# Altium schematic sheet Sheet1.SchDoc
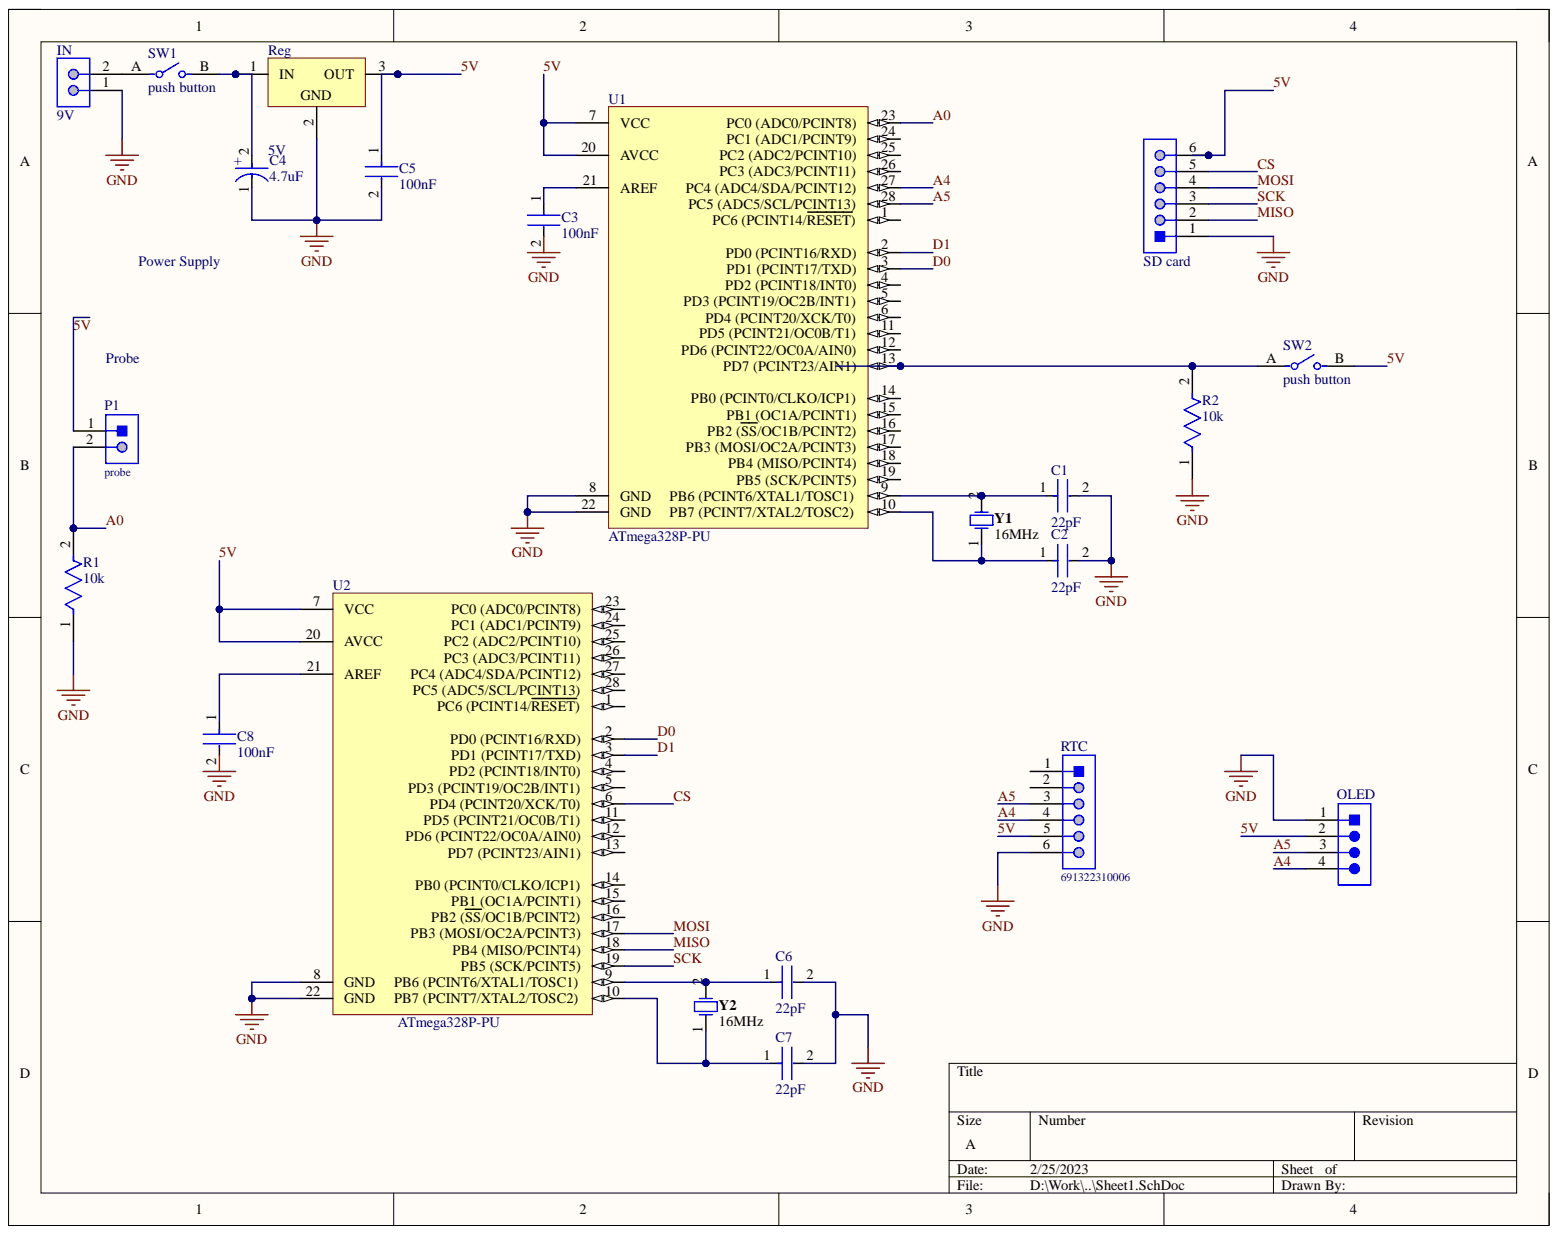
[diagram p0: Sheet1.SchDoc]

## Parts
C1  22pF  [FP-RCE0DB-MFG]
C2  22pF  [FP-RCE0DB-MFG]
C3  100nF  [FP-RCE0DB-MFG]
C4  4.7uF  [FP-RAD-TH-D_6_3_0_5-L_11-MFG]
C5  100nF  [FP-C322C104K5R5TA-MFG]
C6  22pF  [FP-RCE0DB-MFG]
C7  22pF  [FP-RCE0DB-MFG]
C8  100nF  [FP-RCE0DB-MFG]
IN  9V  [MOLX-22-27-2021_V]
OLED  6  [TECO-640456-4]  (value not drawn)
P1  probe  [620302124022]
R1  10k  [FP-CF18-MFG]
R2  10k  [FP-CF18-MFG]
Reg  5V  [TO220]
RTC  691322310006  [691322310006]
SD_card  66200611122  [66200611122]  (value not drawn)
SW1  push button  [FP-EVQ11Y04K-MFG]
SW2  push button  [FP-EVQ11Y04K-MFG]
U1  ATmega328P-PU  [28P3]
U2  ATmega328P-PU  [28P3]
Y1  16MHz  [FOX-FOXSLF160-20]
Y2  16MHz  [FOX-FOXSLF160-20]

## Nets
GND: C1.2 C2.2 C3.2 C4.1 C5.2 C6.2 C7.2 C8.2 IN.1 OLED.1 R1.1 R2.1 Reg.2 RTC.6 SD_card.1 U1.8(GND) U1.22(GND) U2.8(GND) U2.22(GND)
5V: C5.1 OLED.2 P1.1 Reg.3 RTC.5 SD_card.6 SW2.B U1.7(VCC) U1.20(AVCC) U2.7(VCC) U2.20(AVCC)
A0: P1.2 R1.2 U1.23(PC0_(ADC0/PCINT8))
A4: OLED.4 RTC.4 U1.27
A5: OLED.3 RTC.3 U1.28(PC5_(ADC5/SCL/PCINT13))
CS: SD_card.5 U2.6(PD4_(PCINT20/XCK/T0))
D0: U1.3(PD1_(PCINT17/TXD)) U2.2(PD0_(PCINT16/RXD))
D1: U1.2(PD0_(PCINT16/RXD)) U2.3(PD1_(PCINT17/TXD))
MISO: SD_card.2 U2.18(PB4_(MISO/PCINT4))
MOSI: SD_card.4 U2.17(PB3_(MOSI/OC2A/PCINT3))
SCK: SD_card.3 U2.19(PB5_(SCK/PCINT5))
NetC1_1: C1.1 U1.9 Y1.2
NetC2_1: C2.1 U1.10 Y1.1
NetC3_1: C3.1 U1.21(AREF)
NetC4_2: C4.2 Reg.1 SW1.B
NetC6_1: C6.1 U2.9 Y2.2
NetC7_1: C7.1 U2.10 Y2.1
NetC8_1: C8.1 U2.21(AREF)
NetIN_2: IN.2 SW1.A
NetR2_2: R2.2 SW2.A U1.13(PD7_(PCINT23/AIN1))

Not connected: RTC 1 2; U1 1(PC6_(PCINT14/RESET)) 4(PD2_(PCINT18/INT0)) 5(PD3_(PCINT19/OC2B/INT1)) 6(PD4_(PCINT20/XCK/T0)) 11(PD5_(PCINT21/OC0B/T1)) 12(PD6_(PCINT22/OC0A/AIN0)) 14(PB0_(PCINT0/CLKO/ICP1)) 15(PB1_(OC1A/PCINT1)) 16(PB2_(SS/OC1B/PCINT2)) 17(PB3_(MOSI/OC2A/PCINT3)) 18(PB4_(MISO/PCINT4)) 19(PB5_(SCK/PCINT5)) 24(PC1_(ADC1/PCINT9)) 25 26(PC3_(ADC3/PCINT11)); U2 1(PC6_(PCINT14/RESET)) 4(PD2_(PCINT18/INT0)) 5(PD3_(PCINT19/OC2B/INT1)) 11(PD5_(PCINT21/OC0B/T1)) 12(PD6_(PCINT22/OC0A/AIN0)) 13(PD7_(PCINT23/AIN1)) 14(PB0_(PCINT0/CLKO/ICP1)) 15(PB1_(OC1A/PCINT1)) 16(PB2_(SS/OC1B/PCINT2)) 23(PC0_(ADC0/PCINT8)) 24(PC1_(ADC1/PCINT9)) 25 26(PC3_(ADC3/PCINT11)) 27 28(PC5_(ADC5/SCL/PCINT13))

## Library data of the parts
C1 C2 C6 C7 = CMP-06035-047347-1: Cap Ceramic 22pF 100V C0G ±5% Radial 2.5mm +125°C Ammo Pack; Lead Diameter: 0.5mm; Lead/Base Style: Straight; Capacitance: 22pF; Case/Package: Radial; Component Kind: Standard; Depth: 2.5mm; Dielectric: C0G; Height: 3.5mm; Height - Seated (Max): 0.138inch; Lead Pitch: 0.098inch; Length: 3.6mm; Library Name: Altium Content Vault; Max Operating Temperature: 125°C; Min Operating Temperature: -55°C; Mount: Through Hole; Package Quantity: 2000; Packaging: Tape and Reel; Ratings: AEC-Q200; Resistor Type: Ceramic; Termination: Radial; Tolerance: 5%; Voltage: 100V; Voltage Rating: 100V; Voltage Rating (DC): 100V; Width: 0.098inch
C3 C8 = CMP-06035-045506-1: Cap Ceramic 4.7nF 100V X7R ±10% Radial 2.5mm +125°C Ammo Pack; Lead Diameter: 0.5mm; Lead/Base Style: Straight; Capacitance: 100nF; Case/Package: Radial; Component Kind: Standard; Dielectric: X7R; Height: 3.5mm; Height - Seated (Max): 0.138inch; Lead Pitch: 0.098inch; Length: 0.142inch; Library Name: Altium Content Vault; Max Operating Temperature: 125°C; Min Operating Temperature: -55°C; Mount: Through Hole; Package Quantity: 2000; Packaging: Tape and Reel; Ratings: AEC-Q200; Resistor Type: Ceramic; Termination: Radial; Tolerance: 10%; Voltage Rating: 100V; Voltage Rating (DC): 100V; Width: 0.098inch
C4 = CMP-05427-000237-1: Aluminum Electrolytic Capacitors (Radial Lead Type) 4.7uF ±20% 160V; Dielectric Material: Aluminium; Dissipation Factor: 0.15%; Lead Diameter: 0.5mm; Lead/Base Style: Straight; Life (Hours): 2000Hour; Ripple Current: 43mA; Capacitance: 4.7uF; Case/Package: Radial; Component Kind: Standard; Construction: RADIAL; Diameter: 6.3mm; Height: 11.2mm; Height - Seated (Max): 0.48inch; Lead Free: Lead Free; Lead Pitch: 2.5mm; Length: 11.2mm; Library Name: Altium Content Vault; Max Operating Temperature: 105°C; Min Operating Temperature: -25°C; Mount: Through Hole; Number of Pins: 2; Package Quantity: 200; Packaging: Bulk; Radiation Hardening: No; REACH SVHC: No SVHC; Ripple Current (AC): 43mA; RoHS Compliant: Yes; Series: NHG; Termination: Radial; Tolerance: 20%; Voltage: 160V; Voltage Rating: 160V; Voltage Rating (DC): 160V; Weight: 0.01lb; Width: 0.25inch
C5 = CMP-03020-034661-1: CAP CER 0.1UF 50V X7R RADIAL; Dielectric Material: Ceramic; Dissipation Factor: 2.5%; Lead Diameter: 0.51mm; Lead Length: 7mm; Lead/Base Style: Formed Leads; Tolerance: 10%; Capacitance: 100nF; Case/Package: Radial; Component Kind: Standard; Construction: RADIAL; Depth: 3.18mm; Dielectric: X7R; Height: 3.18mm; Height - Seated (Max): 0.26inch; Lead Free: Lead Free; Lead Pitch: 5.08mm; Length: 5.08mm; Library Name: Altium Content Vault; Material: Ceramic; Max Operating Temperature: 125°C; Min Operating Temperature: -55°C; Mount: Through Hole; Number of Pins: 2; Package Quantity: 500; Packaging: Bulk; Radiation Hardening: No; REACH SVHC: No SVHC; RoHS Compliant: Yes; Series: Golden Max™; Termination: Radial; Voltage: 50V; Voltage Rating: 50V; Voltage Rating (DC): 50V; Weight: 0.0625lb; Width: 0.125inch
IN = CMP-2000-05537-1: Male Header, Pitch 2.54 mm, 1 x 2 Position, Height 11.7 mm, Tail Length 3.56 mm, 0 to 75 degC, RoHS, Bulk; Manufacturer: Molex; PartNumber: 0022272021; Current - Max: 4A; No. of Positions: 2; No. of Rows: 1; Package Version: Rev. BC, 02/2012; Pitch: 2.54mm; Voltage - Max: 250V; Component Kind: Standard; Contact material: Tinplatedbrass; Current rating: 4A; Datasheet URL: http://www.molex.com/pdm_docs/sd/022272021_sd.pdf; Datasheet Version: Rev. F, 06/2009; Housing material: Nylon(UL94V-0); Library Name: Altium Content Vault; Manufacturer URL: http://www.molex.com/; Mounting Technology: ThroughHole; Operating temperature: 0°Cto+75°C; Orientation: Vertical; Package Description: 2-Leads Header, Body 5.08 x 5.8 mm, Pitch 2.54 mm; Package Reference: 22-27-2021; Voltage rating: 250V
OLED = CMP-2000-05419-1: Male Header, Pitch 2.54 mm, 1 x 4 Position, Height 10.03 mm, Tail Length 3.56 mm, RoHS, Bulk; Manufacturer: TE Connectivity; Agency Approvals: CSA,UL; Angle: Straight; Brand/Series: MTA-100Series; Color, Housing: White; Connector Type: MTA-100; Contact Centerline Spacing: 0.100    in.; Contact Termination Area Plating: Tin; Current, Rating: 5    A; Material, Contact: CopperAlloy; Material, Housing: Polyester; Mount Angle: Vertical; Mounting Hole Size: 0.04    "; Number of Contacts: 4; Pin Spacing: 0.1    "; Primary Type: InterconnectSystem; Special Features: Pinstrip; Standards: RoHSCompliant,ELVCompliant,UL94V-0; Temperature, Operating: -55to+105    degC; Voltage, Rating: 250    V; Color: White; Component Kind: Standard; Contact Mating Area Plating: Tin; Contact Plating: Tin; Contact Shape: Square; Datasheet URL: https://datasheet.ciiva.com/16286/eng-ds-82056-mta-0608-16286228.pdf; Datasheet Version: Dec-14; Flammability Rating: UL94V-0; Length, Overall: 0.4    "; Length, Pin: 0.14    "; Length, Terminal Post: 0.14    in.; Library Name: Altium Content Vault; Manufacturer URL: http://www.te.com/; Mounting Type: PCMount; Number of Positions: 4; Number of Rows: 1; Size, Post: 0.025    in.; Termination: Solder; Type: PCBPlug
P1 = CMP-1502-00660-1: Male Shrouded Header WR-WTB, SMT, Vertical, pitch 2 mm, 1 x 2 position; Manufacturer: Wurth Elektronik; Contact Resistance: 20mOhm; Pitch: 2mm; Position: 1x2; Working Voltage: 100VAC; Component Kind: Standard; Datasheet URL: https://katalog.we-online.de/em/datasheet/6203xx124022.pdf; Gender: Male; Library Name: Altium Content Vault; Manufacturer URL: http://www.we-online.de; Mounting Technology: SMT; Operating Temperature: -25to85degC; Orientation: Vertical; Package Reference: 620302124022; Packaging: TapeandReel; Part Number: 620302124022; Publisher: WurthElektronik
R1 R2 = CMP-26528-000002-1: RES 10K OHM 1/8W 5% CF AXIAL; Manufacturer: Stackpole Electronics; Manufacturer Part Number: CF18JT10K0; Power Rating: 0.125W; Resistance: 10kΩ; Temperature Coefficient: 0-500ppm/°C; Value: 10kΩ; Voltage Rating: 250V; Automotive: No; Category: Res; Component Kind: Standard; ComponentLink2Description: Datasheet; ComponentLink2URL: http://www.seielect.com/Catalog/SEI-CF_CFM.pdf; Composition: Carbon Film; Device Class L1: Passive Components; Device Class L2: Resistors; Device Class L3: Through-Hole Resistors; Digikey Description: RES 10K OHM 1/8W 5% CF AXIAL; Height: 2mm; Ipc Land Pattern Name: RESAD656W65L360D200; Lead Free: Yes; Library Name: Altium Content Vault; Octopart: https://octopart.com/cf18jt10k0-stackpole+electronics-19205642; Package: CF18; RoHS: TRUE; Series: CF; Temperature: -55°C to +155°C; Temperature Range High: +155°C; Temperature Range Low: -55°C; Tolerance: 5%
Reg = CMP-0244-00495-1: Positive Voltage Regulator, 6V, 3-Pin TO-220; Component Kind: Standard; ComponentLink1Description: Manufacturer URL; ComponentLink1URL: http://www.st.com; ComponentLink2Description: Datasheet; ComponentLink2URL: http://www.st.com/internet/com/TECHNICAL_RESOURCES/TECHNICAL_LITERATURE/DATASHEET/CD00000444.pdf; ComponentLink3Description: Package Specification; ComponentLink3URL: http://www.st.com/internet/com/TECHNICAL_RESOURCES/TECHNICAL_LITERATURE/PACKAGE_INFORMATION/CD00004649.pdf; DatasheetVersion: 16/11/2011; Library Name: Altium Content Vault; PackageDescription: TO, Thru-Hole, Vertical, Heatsink Mounted; 3 In-Line Leads; Pitch 2.54 mm; PackageReference: TO220; PackageVersion: ver3.0
RTC = CMP-1502-01890-1: Serie 322 - 3.81mm Horizontal PCB Header WR-TBL, 6 pin; Manufacturer: Wurth Elektronik; Gender: Male; Pin Number: 6; Component Kind: Standard; Datasheet URL: http://katalog.we-online.de/em/datasheet/6913223100xx.pdf; Library Name: Altium Content Vault; Manufacturer URL: http://www.we-online.de; Mounting Technology: THT; Operating Temperature: -40to105degC; Package Reference: 691322310006; Packaging: Box; Part Number: 691322310006; Pitch: 3.81mm; Publisher: WurthElektronik
SD_card = CMP-1502-00444-1: THT Male Header WR-MPC3, Vertical, Single Row, pitch 3 mm, 6 pins; Manufacturer: Wurth Elektronik; Contact Resistance: 10mOhm; Pin Number: 6; Pitch: 3mm; Working Voltage: 250VAC; Component Kind: Standard; Datasheet URL: https://katalog.we-online.de/em/datasheet/6620xx11122.pdf; Gender: Male; Library Name: Altium Content Vault; Manufacturer URL: http://www.we-online.de; Mounting Technology: THT; Operating Temperature: -40to105degC; Orientation: Vertical; Package Reference: 66200611122; Packaging: Tube; Part Number: 66200611122; Publisher: WurthElektronik
SW1 SW2 = CMP-05618-000106-1: SWITCH TACTILE SPST-NO 0.02A 15V; Actuator Color: Black; Actuator Length: 0.4mm; Actuator Type: Standard; Contact Resistance: 50mR; Electrical Life: 1000000Cycles; Illumination Type: Non-Illuminated; Operating Force: 1.6N; Switch Function: Off-Mom; Switch Travel: 0.25mm; Throw Configuration: SPST; Case/Package: Radial; Component Kind: Standard; Lead Free: Lead Free; Library Name: Altium Content Vault; Max Operating Temperature: 70°C; Max Voltage Rating (DC): 15V; Mechanical Life: 1000000Cycles; Min Operating Temperature: -20°C; Mount: Through Hole; Orientation: Straight; Package Quantity: 1; Packaging: Tape and Reel; Radiation Hardening: No; RoHS Compliant: Yes; Series: EVQ11; Size: 6mm; Termination: PC Pin
U1 U2 = CMP-0095-00013-2: 8-bit AVR Microcontroller, 32KB Flash, 1KB EEPROM, 2KB SRAM, 28-pin PDIP, Industrial Grade (-40°C to 85°C); Component Kind: Standard; ComponentLink1Description: Manufacturer URL; ComponentLink1URL: http://www.atmel.com/; ComponentLink2Description: ATmega48A/48PA/88A/88PA/168A/168PA/328/328P Datasheet; ComponentLink2URL: http://www.atmel.com/dyn/resources/prod_documents/8271.pdf; ComponentLink3Description: ATmega48A/48PA/88A/88PA/168A/168PA/328/328P Summary; ComponentLink3URL: http://www.atmel.com/dyn/resources/prod_documents/8271S.pdf; DatasheetVersion: revD, May-2011; Library Name: Altium Content Vault; PackageDescription: 28-lead (0.300"/7.62mm Wide) Plastic Dual Inline Package (PDIP); PackageReference: 28P3; PackageVersion: revB, Sep-2001; RevisionNote: DatasheetVersion updated. URLs added.
Y1 Y2 = CMP-1749-00003-1: Resistance Weld Thru-Hole Crystal, 16 MHz, -20 to 70 degC, 2-Pin THD, RoHS, Bulk; Case\Package: Axial; Power Rating: 250 mW; Resistance: 221 kohm; Tolerance: 1%; Voltage Rating: 250 V; Component Kind: Standard; ComponentLink1Description: Manufacturer URL; ComponentLink1URL: http://www.foxonline.com/; ComponentLink2Description: Datasheet; ComponentLink2URL: http://www.foxonline.com/pdfs/hc49slf.pdf; DatasheetVersion: Mar-15; Length: 0.248 inch; Library Name: Altium Content Vault; Max Operating Temperature: 155 degC; Min Operating Temperature: -55 degC; Mount: Through Hole; Package Quantity: 200; PackageDescription: 2-Pin Through Hole Device, Body 11.35 x 4.65 mm; PackageReference: FOXSLF160-20; Packaging: Bulk; RoHSCompliant: true
